# Altium schematic sheet PAGE1.SchDoc
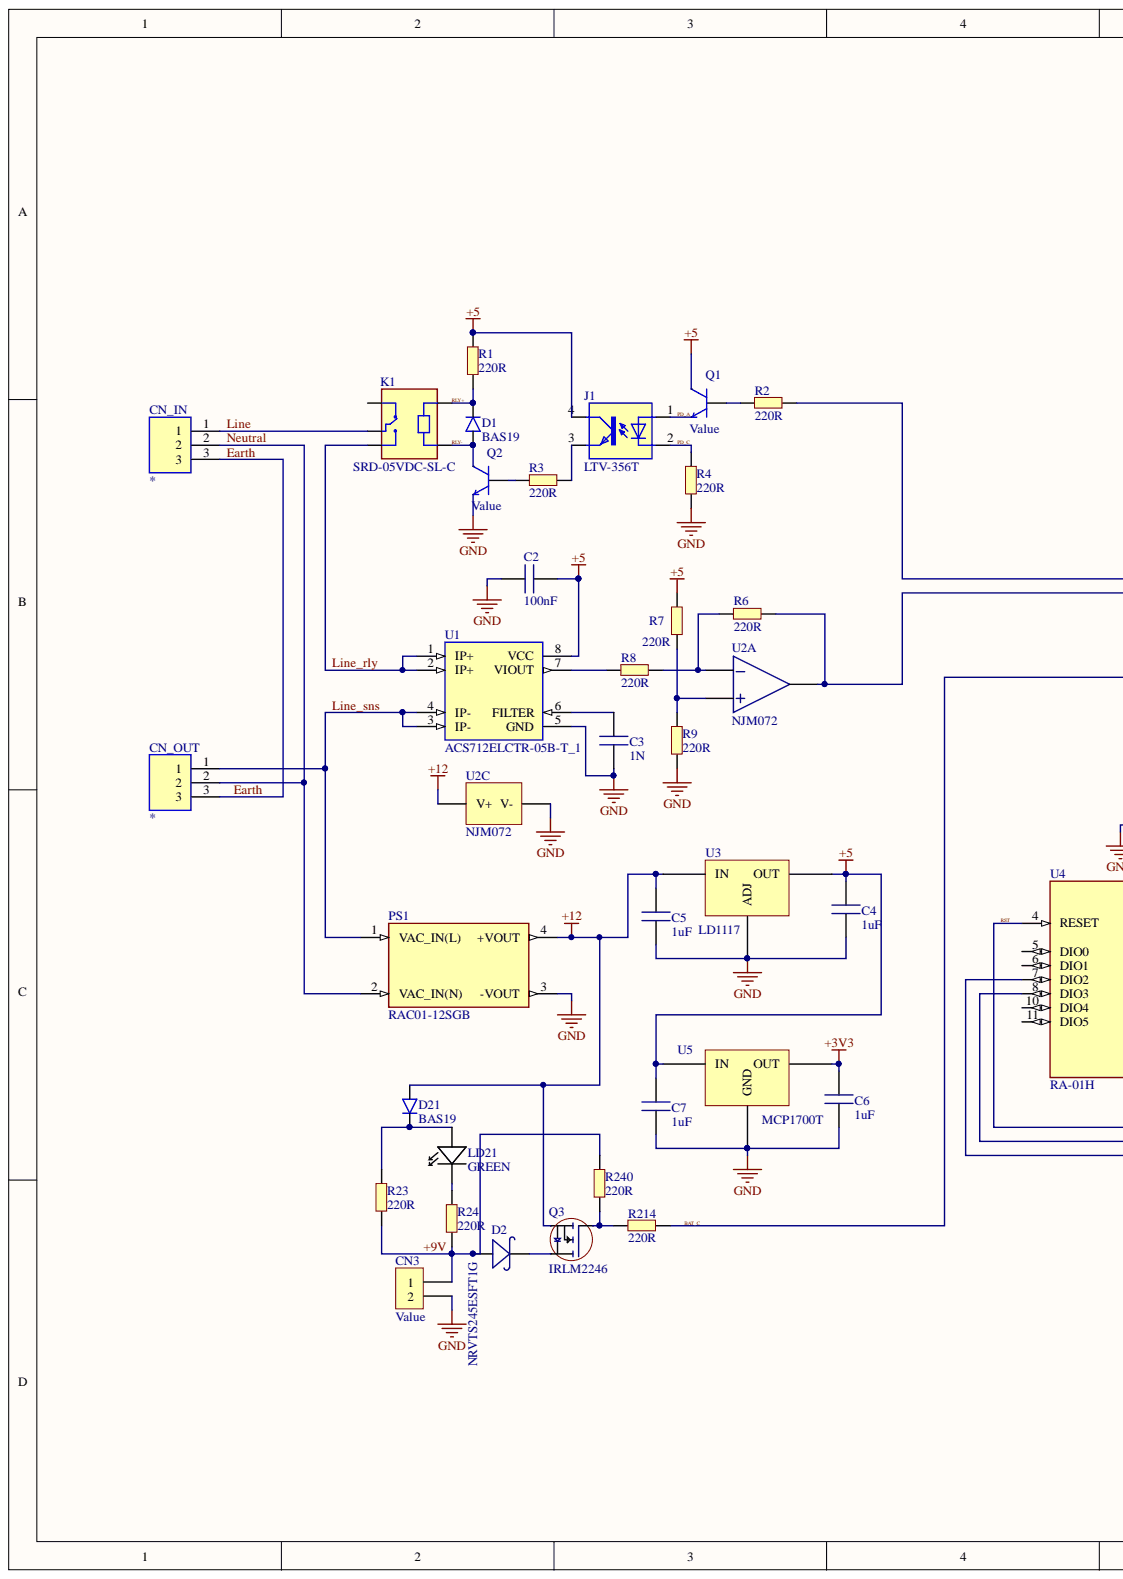
[diagram p0: PAGE1.SchDoc, left]
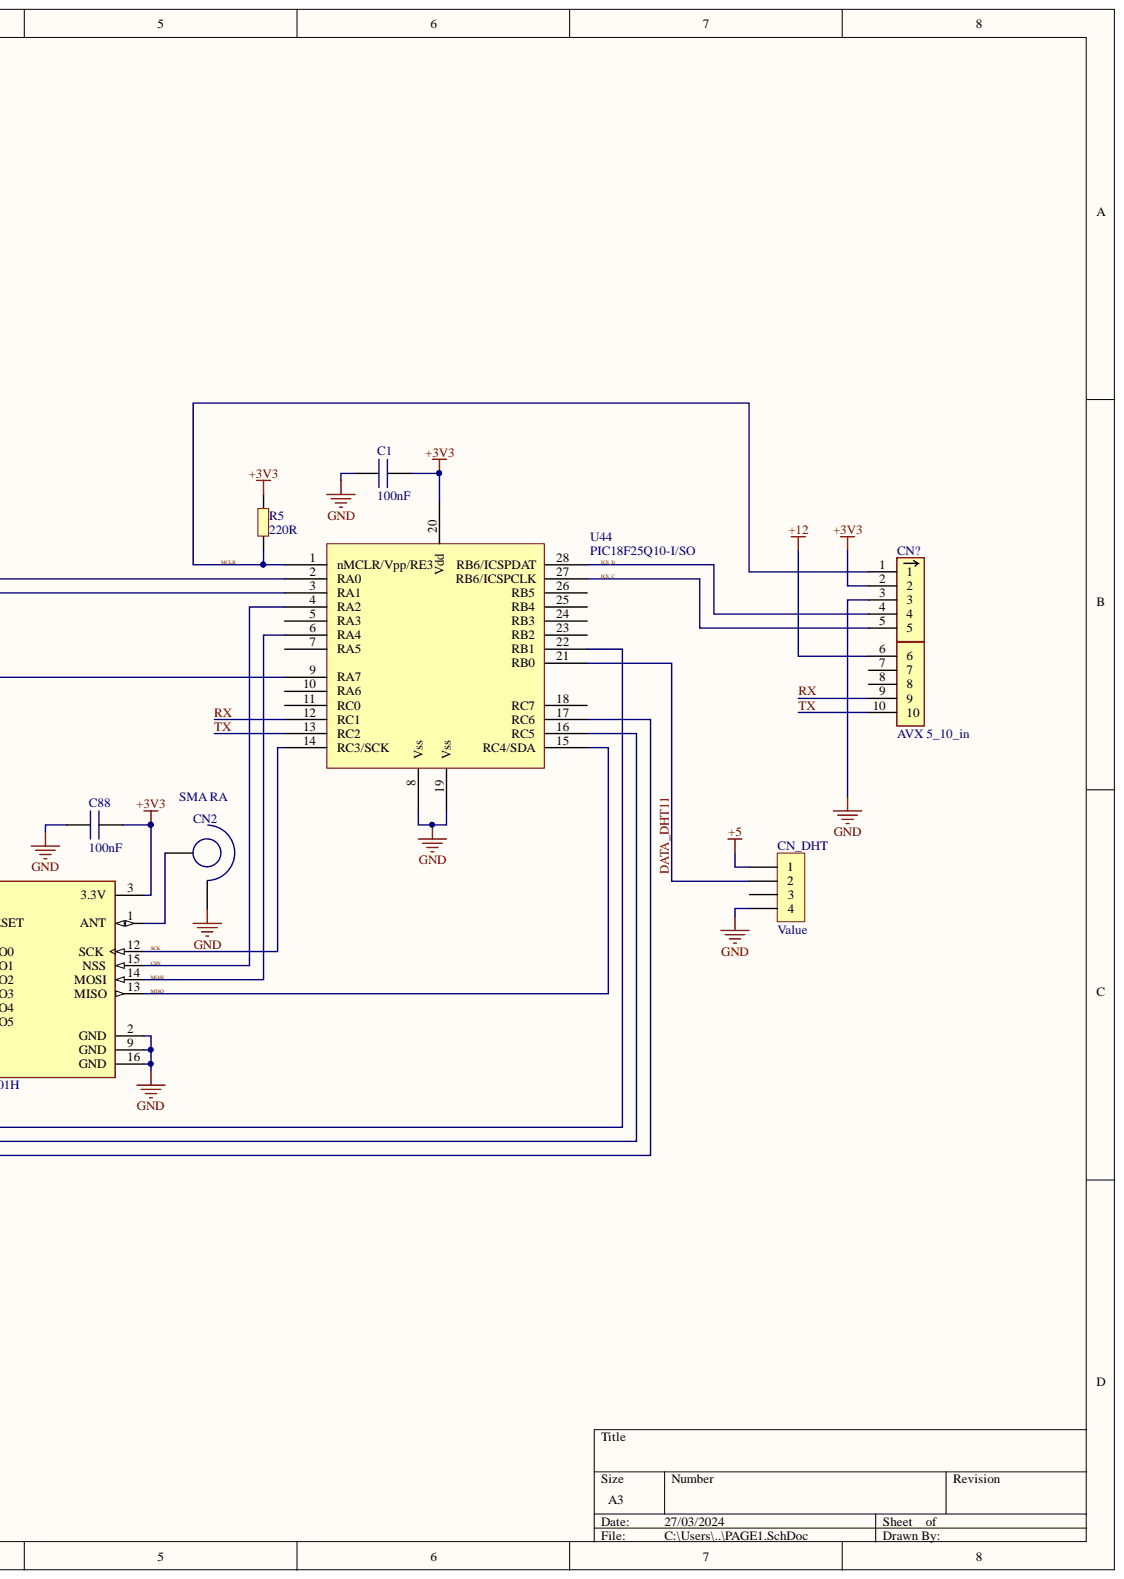
[diagram p1: PAGE1.SchDoc, right]

## Parts
C1  100nF  [0603]
C2  100nF  [0603]
C3  1N  [0603]
C4  1uF  [0603]
C5  1uF  [0603]
C6  1uF  [0603]
C7  1uF  [0603]
C88  100nF  [0603]
CN2  SMA RA  [LPRS SMA RA]
CN3  Value  [THT_Hdr_JST_XH_Fm_2x1_0.1_RA]
CN?  AVX 5_10_in  MF: AVX  [AVX_10_in]
CN_DHT  Value  [THT_Hdr_JST_XH_Fm_4x1_0.1_RA]
CN_IN  282841-3  [TE_282841-3]  (value not drawn)
CN_OUT  282841-3  [TE_282841-3]  (value not drawn)
D1  BAS19  [SOT-23]
D2  NRVTS245ESFT1G  [SMA]
D21  BAS19  [SOT-23]
J1  LTV-356T  [MINI-FLAT-4]
K1  SRD-05VDC-SL-C  [RELAY_SRD-05VDC-SL-C]
LD21  GREEN  [LED 3X1.5]
PS1  RAC01-12SGB  [CONV_RAC01-12SGB]
Q1  Value  [[0301]BC846B_SOT23]
Q2  Value  [[0301]BC846B_SOT23]
Q3  IRLM2246  [SOT-23]
R1  220R  [0603]
R2  220R  [0603]
R3  220R  [0603]
R4  220R  [0603]
R5  220R  [0603]
R6  220R  [0603]
R7  220R  [0603]
R8  220R  [0603]
R9  220R  [0603]
R23  220R  [0603]
R24  220R  [0603]
R214  220R  [0603]
R240  220R  [0603]
U1  ACS712ELCTR-05B-T_1  [SOIC127P600X175-8N]
U2  NJM072  [SO-8]  (parts A,C)
U3  LD1117  [SOT223]
U4  RA-01H  [XCVR_RA-01H]
U5  MCP1700T  [SOT-23]
U44  PIC18F25Q10-I/SO  [SOIC-28]

## Nets
+3V3: C1.1 C6.2 C88.1 CN?.2 R5.2 U4.3(3.3V) U5.2 U44.20(Vdd)
+5: C2.1 C4.2 C7.2 CN_DHT.1 J1.4 Q1.3 R1.2 R7.2 U1.8(VCC) U3.2 U5.3
+12: C5.2 CN?.6 D21.1 PS1.4(+VOUT) Q3.2 U2.8(V+) U3.3
GND: C1.2 C2.2 C3.2 C4.1 C5.1 C6.1 C7.1 C88.2 CN2.2 CN3.2 CN?.3 CN_DHT.4 PS1.3(-VOUT) Q2.2 R4.2 R9.2 U1.5(GND) U2.4(V-) U3.1 U4.2(GND) U4.9(GND) U4.16(GND) U5.1 U44.8(Vss) U44.19(Vss)
+9V: CN3.1 D2.1 R23.2 R24.2 R240.1
BAT_C: R214.2 U44.9(RA7)
CSN: U4.15(NSS) U44.4(RA2)
DATA_DHT11: CN_DHT.2 U44.21(RB0)
EARTH: CN_IN.3 CN_OUT.3
ICS_C: CN?.5 U44.27(RB6/ICSPCLK)
ICS_D: CN?.4 U44.28(RB6/ICSPDAT)
LINE: CN_IN.1 K1.COM
LINE_RLY: K1.NO U1.1(IP+) U1.2(IP+)
LINE_SNS: CN_OUT.1 PS1.1(VAC_IN(L)) U1.3(IP-) U1.4(IP-)
MCLR: CN?.1 R5.1 U44.1(nMCLR/Vpp/RE3)
MISO: U4.13(MISO) U44.15(RC4/SDA)
MOSI: U4.14(MOSI) U44.6(RA4)
NEUTRAL: CN_IN.2 CN_OUT.2 PS1.2(VAC_IN(N))
PD_A: J1.1 Q1.2
PD_C: J1.2 R4.1
RLY+: D1.3 K1.A2 R1.1
RLY-: D1.1 K1.A1 Q2.3
RST: U4.4(RESET) U44.22(RB1)
RX: CN?.9 U44.12(RC1)
SCK: U4.12(SCK) U44.14(RC3/SCK)
TX: CN?.10 U44.13(RC2)
NetC3_1: C3.1 U1.6(FILTER)
NetCN2_1: CN2.1 U4.1(ANT)
NetD2_2: D2.2 Q3.3
NetD21_3: D21.3 LD21.1 R23.1
NetJ1_3: J1.3 R3.1
NetLD21_2: LD21.2 R24.1
NetQ1_1: Q1.1 R2.1
NetQ2_1: Q2.1 R3.2
NetQ3_1: Q3.1 R214.1 R240.2
NetR2_2: R2.2 U44.2(RA0)
NetR6_1: R6.1 R8.2 U2.2
NetR6_2: R6.2 U2.1 U44.3(RA1)
NetR7_1: R7.1 R9.1 U2.3
NetR8_1: R8.1 U1.7(VIOUT)
NetU4_7: U4.7(DIO2) U44.17(RC6)
NetU4_8: U4.8(DIO3) U44.16(RC5)

Not connected: CN? 7 8; CN_DHT 3; K1 NC; U4 5(DIO0) 6(DIO1) 10(DIO4) 11(DIO5); U44 5(RA3) 7(RA5) 10(RA6) 11(RC0) 18(RC7) 23(RB2) 24(RB3) 25(RB4) 26(RB5)

## Library data of the parts
C1-C3 C88 = CAP 0603 100nF: Standard 100nF 25V 10% X7R 0603; MN: CC0603KRX7R9BB104; Component Kind: Standard; Dielectric: X7R; Farnell: 2530141; LCSC: C14663; Library Name: [0002]CAP0603_SMD_100n.IntLib; Tolerance: 10%; Value: 100nF
C4-C7 = CAP 0603 1uF: 16V 1uF X7R ±10% 0603 MLCC; MN: Samwha Capacitor; MP: CS1608X7R105K160NRB; Component Kind: Standard; Dielectric: X7R; LCSC: C2918499; Library Name: [0002]CAP0603_SMD_1uF.IntLib; Tolerance: 10%; Value: 1uF
CN2 = SMA RA: RA SMA Connector; UA P/N: 209-6224; Component Kind: Standard; Library Name: Connectors$.IntLib; Type: LPRS SMA RA CONNECTOR; Value: SMA RA
CN3 = THT_Hdr_JST_XH_Fm_2x1_0.1_RA: JST XH Through-hole Header female 2x1 pitch 0.1'' Right angle; Component Kind: Standard; Library Name: [0507]THT_Hdr_JST_XH_Fm_2x1_0.1_RA.IntLib
CN? = AVX 5_10_in: MP: 009159010061916; Availability: Unavailable; Component Kind: Standard; Digikey: 478-8659-ND; Mouser: 581-009159010061916; Package: None; Price: None; Library Name: [1002]AVX_DUAL_10_CONTACTS.SchLib
CN_DHT = THT_Hdr_JST_XH_Fm_4x1_0.1_RA: JST XH Through-hole Header female 4x1 pitch 0.1'' Right angle; Component Kind: Standard; Library Name: [0507]THT_Hdr_JST_XH_Fm_4x1_0.1_RA.IntLib
CN_IN CN_OUT = 282841-3: Conn Terminal Block 3 POS 5.08mm Solder ST Thru-Hole 17.5A/Contact; MF: TE Connectivity; Availability: In Stock; Check_prices: https://www.snapeda.com/parts/282841-3/TE+Connectivity+AMP+Connectors/view-part/?ref=eda; Component Kind: Standard; EU_RoHS_Compliance: Compliant with Exemptions; LCSC: C474886; Library Name: [0503]282841-3.IntLib; MP: 282841-3; Package: None; Price: None; Purchase-URL: https://pricing.snapeda.com/search?q=282841-3&ref=eda; SnapEDA_Link: https://www.snapeda.com/parts/282841-3/TE+Connectivity+AMP+Connectors/view-part/?ref=snap
D1 D21 = BAS19: Diode Standard 250V 200mA Surface Mount SOT-23-3 _TO-236_; MF: onsemi; MP: BAS19LT1G; Availability: Unavailable; Component Kind: Standard; LCSC: C232582; Library Name: [0304]BAS19_SOT23.IntLib; Package: SOT-23-3 Rectron; Price: US$0.0277; SnapEDA_Link: https://www.snapeda.com/parts/BAS21/ON%20Semiconductor/view-part/2747894/?ref=snap; Value: BAS19
D2 = NRVTS245ESFT1G: Schottky Rectifier, 45 V, 2 A, Single, SOD-123, 2 Pins, 650 mV; Type: SMD DIODE SHOTTKY 45V 2A; UA P/N: 15-0042; Component Kind: Standard; Library Name: [0304] Diodes.IntLib; Value: NRVTS245ESFT1G
J1 = LTV-356T: Optoisolator Transistor Output 3750Vrms 1 Channel 4-SOP; MF: Lite-On Inc.; Availability: In Stock; Check_prices: https://www.snapeda.com/parts/LTV-356T/Lite-On+Inc./view-part/?ref=eda; Component Kind: Standard; LCSC: C125117; Library Name: [0402]LTV-356T.IntLib; MP: LTV-356T; Package: SOP-4 Lite-On Inc.; Price: None; Purchase-URL: https://pricing.snapeda.com/search/part/LTV-356T/?ref=eda; SnapEDA_Link: https://www.snapeda.com/parts/LTV-356T/Lite-On+Inc./view-part/?ref=snap
K1 = SRD-05VDC-SL-C: 5V Trigger Relay Module For Arduino And Raspberry Pi 5V Trigger Relay Module For Arduino And Raspberry Pi; MF: Songle Relay; Availability: Not in stock; Check_prices: https://www.snapeda.com/parts/SRD-05VDC-SL-C/Songle+Relay/view-part/?ref=eda; Component Kind: Standard; LCSC: C140615; Library Name: SRD-05VDC-SL-C.IntLib; MP: SRD-05VDC-SL-C; Package: NON STANDARD-5 Songle Relay; Price: None; SnapEDA_Link: https://www.snapeda.com/parts/SRD-05VDC-SL-C/Songle+Relay/view-part/?ref=snap
LD21 = LED GREEN: Standard LED 1206; Type: KINGBRIGHT; UA P/N: 15-0020; Component Kind: Standard; Library Name: [0401] LEDs.IntLib; Value: GREEN
PS1 = RAC01-12SGB: AC/DC Converter, 1 Watt, Single Output, EMC Class B; Availability: Unavailable; Component Kind: Standard; LCSC: TDPOWER TAS3-12-WEDT; Library Name: [0906]RAC01-12SGB.IntLib; MP: RAC01-12SGB; Package: None; Price: None
Q1 Q2 = NPN SOT-23: NPN SOT-23 transistor [0301]BC846B_SOT23; Component Kind: Standard; Library Name: [0301]BC846B_SOT23.IntLib; Simulation: NPN_Mod_Gummel_Poon
Q3 = IRLML2246: N Channel MOSFET, SOT-23, Simulation; UA P/N: 15-0064; Component Kind: Standard; Library Name: [0303] MOSFETs.IntLib; Simulation: IRLML2246TRPBF; Value: IRLM2246
R1-R9 R23 R24 R214 R240 = RES0603_220R: 1/10W ±1% 220Ω ±100ppm/℃ 0603; MN: Ever Ohms Tech; MP: CR0603F220RP05Z; UA P/N: 13-0004; Component Kind: Standard; LCSC: C881327; Library Name: [0001]RES0603_SMD_220R.IntLib; Price: US$0.0018; Type: THICK FILM; Value: 220R
U1 = ACS712ELCTR-05B-T_1: Current Sensor 5A 1 Channel Hall Effect, Open Loop Bidirectional 8-SOIC (0.154, 3.90mm Width); MF: Allegro; Availability: In Stock; Check_prices: https://www.snapeda.com/parts/ACS712ELCTR-05B-T/Allegro%20Industries/view-part/330078/?ref=eda; Component Kind: Standard; LCSC: C44471; Library Name: [0603]ACS712ELCTR-05B-T.SchLib; MP: ACS712ELCTR-05B-T; Package: SOIC-8 Allegro MicroSystems LLC; Price: None; SnapEDA_Link: https://www.snapeda.com/parts/ACS712ELCTR-05B-T/Allegro%20Industries/view-part/330078/?ref=snap
U2 = NJM072: Dual OPAMP SOIC Package; Component Kind: Standard; Library Name: [0101]NJM072CG_TE2_SOIC127P_600X175-8N.IntLib; Simulation: IDEALOPAMP_3NODES; Value: NJM072
U3 = LD1117-3.3: LD1117STR Adjustable 1.25V-15V LDO, 800mA; UA P/N: 146-7776; Component Kind: Standard; Library Name: [0102] Voltage Regulator - Linear.IntLib; Type: LDO; Value: LD1117-3.3
U4 = RA-01H: MÃ´-Ä‘un thu phÃ¡t LoRaâ„¢ ISM < 1GHz chung KhÃ´ng bao gá»“m Äƒng-ten 803 MHz ~ 930 MHz GiÃ¡ treo bá» máº·t; MF: AI-Thinker; Check_prices: https://www.snapeda.com/parts/RA-01H/AI-Thinker/view-part/?ref=eda; Component Kind: Standard; LCSC: C503593; Library Name: [0115]RA-01H_XCVR.IntLib; MP: RA-01H; Package: Package; SnapEDA_Link: https://www.snapeda.com/parts/RA-01H/AI-Thinker/view-part/?ref=snap
U5 = MCP1700T: UA P/N: 1296592; Component Kind: Standard; Description: MCP1700T; Library Name: [0102] Voltage Regulator - Linear.IntLib; Type: 3V3 LDO REGULATOR; Value: MCP1700T
U44 = PIC18F25Q10-I/SO: PIC 18F25Q10-I/SO, SOIC 28 pins, MCU8, 16Kb flash, 1Kb RAM, 256B EEPROM; Component Kind: Standard; Farnell: 3380497; LatestRevisionDate: 17-Sep-2020; Library Name: [0107] Microcontrollers.IntLib; Value: PIC18F25Q10-I/SO; LatestRevisionNote: Created by Adrian
